# Altium schematic sheet Commodore CPM Modul.SchDoc("Commodore CPM Modul")
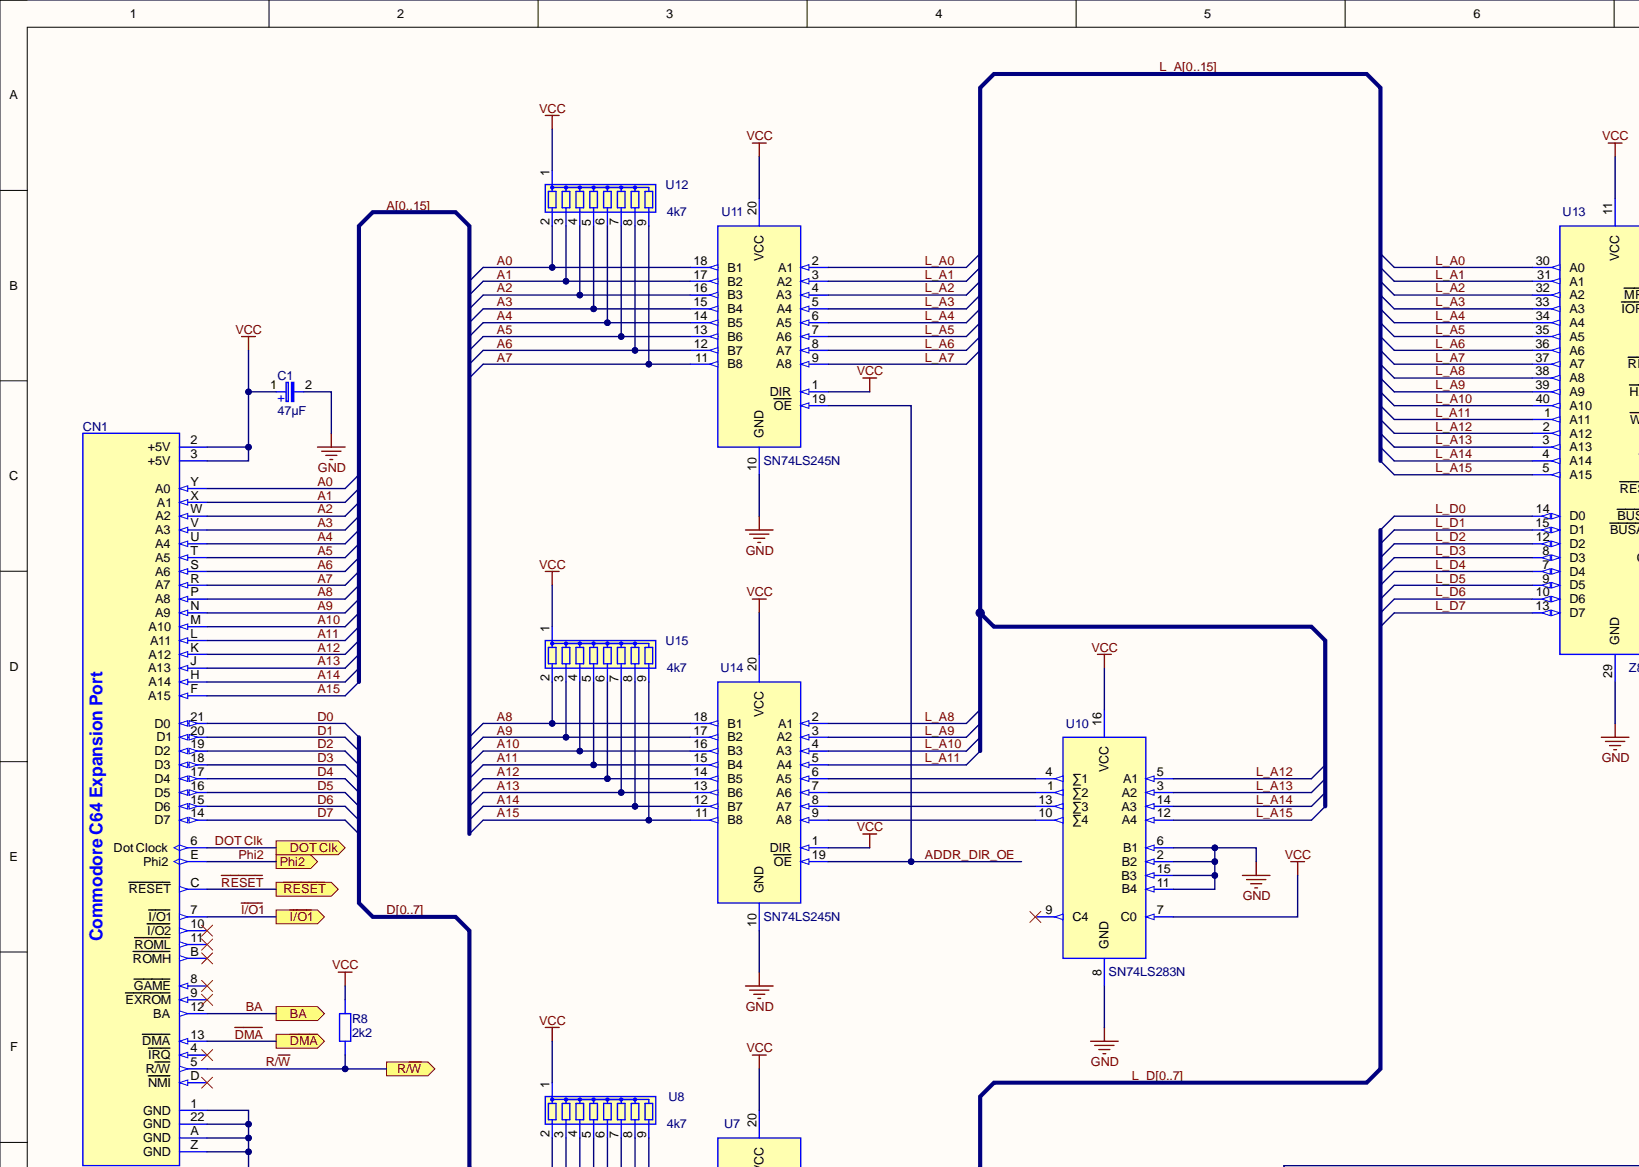
[diagram p0: Commodore CPM Modul.SchDoc, top left]
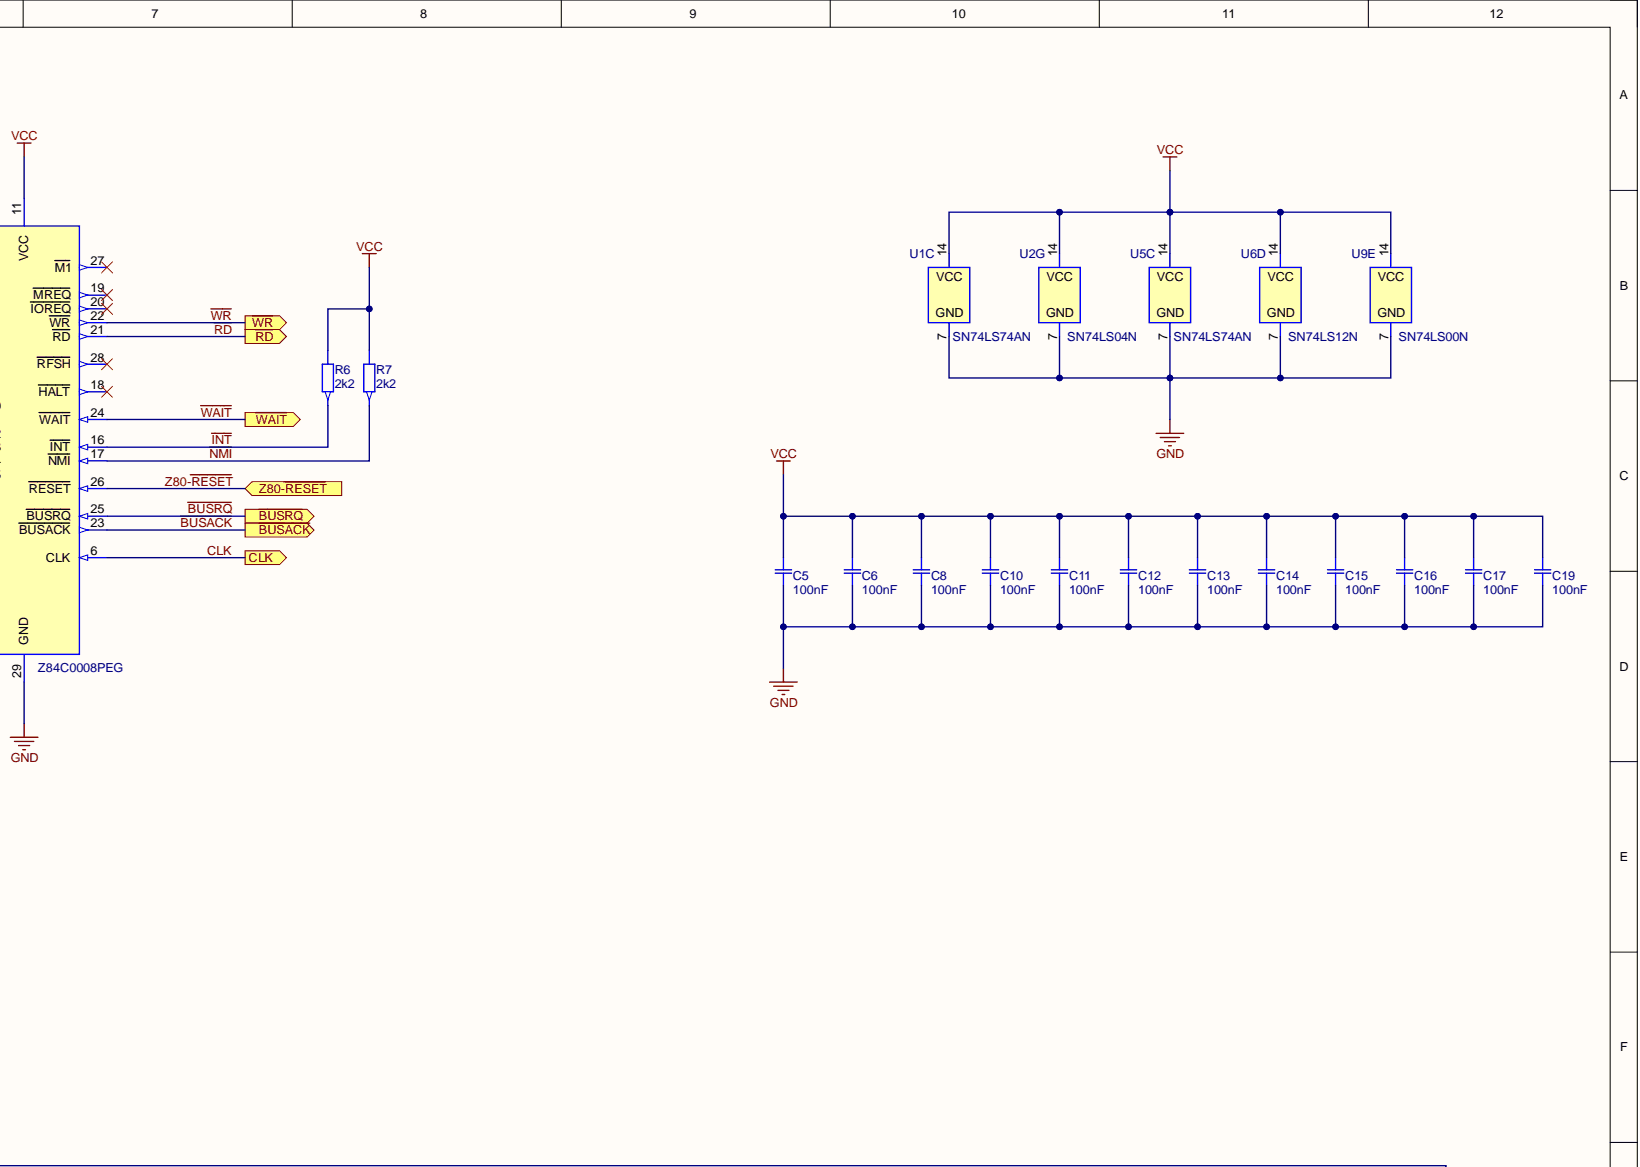
[diagram p1: Commodore CPM Modul.SchDoc, top right]
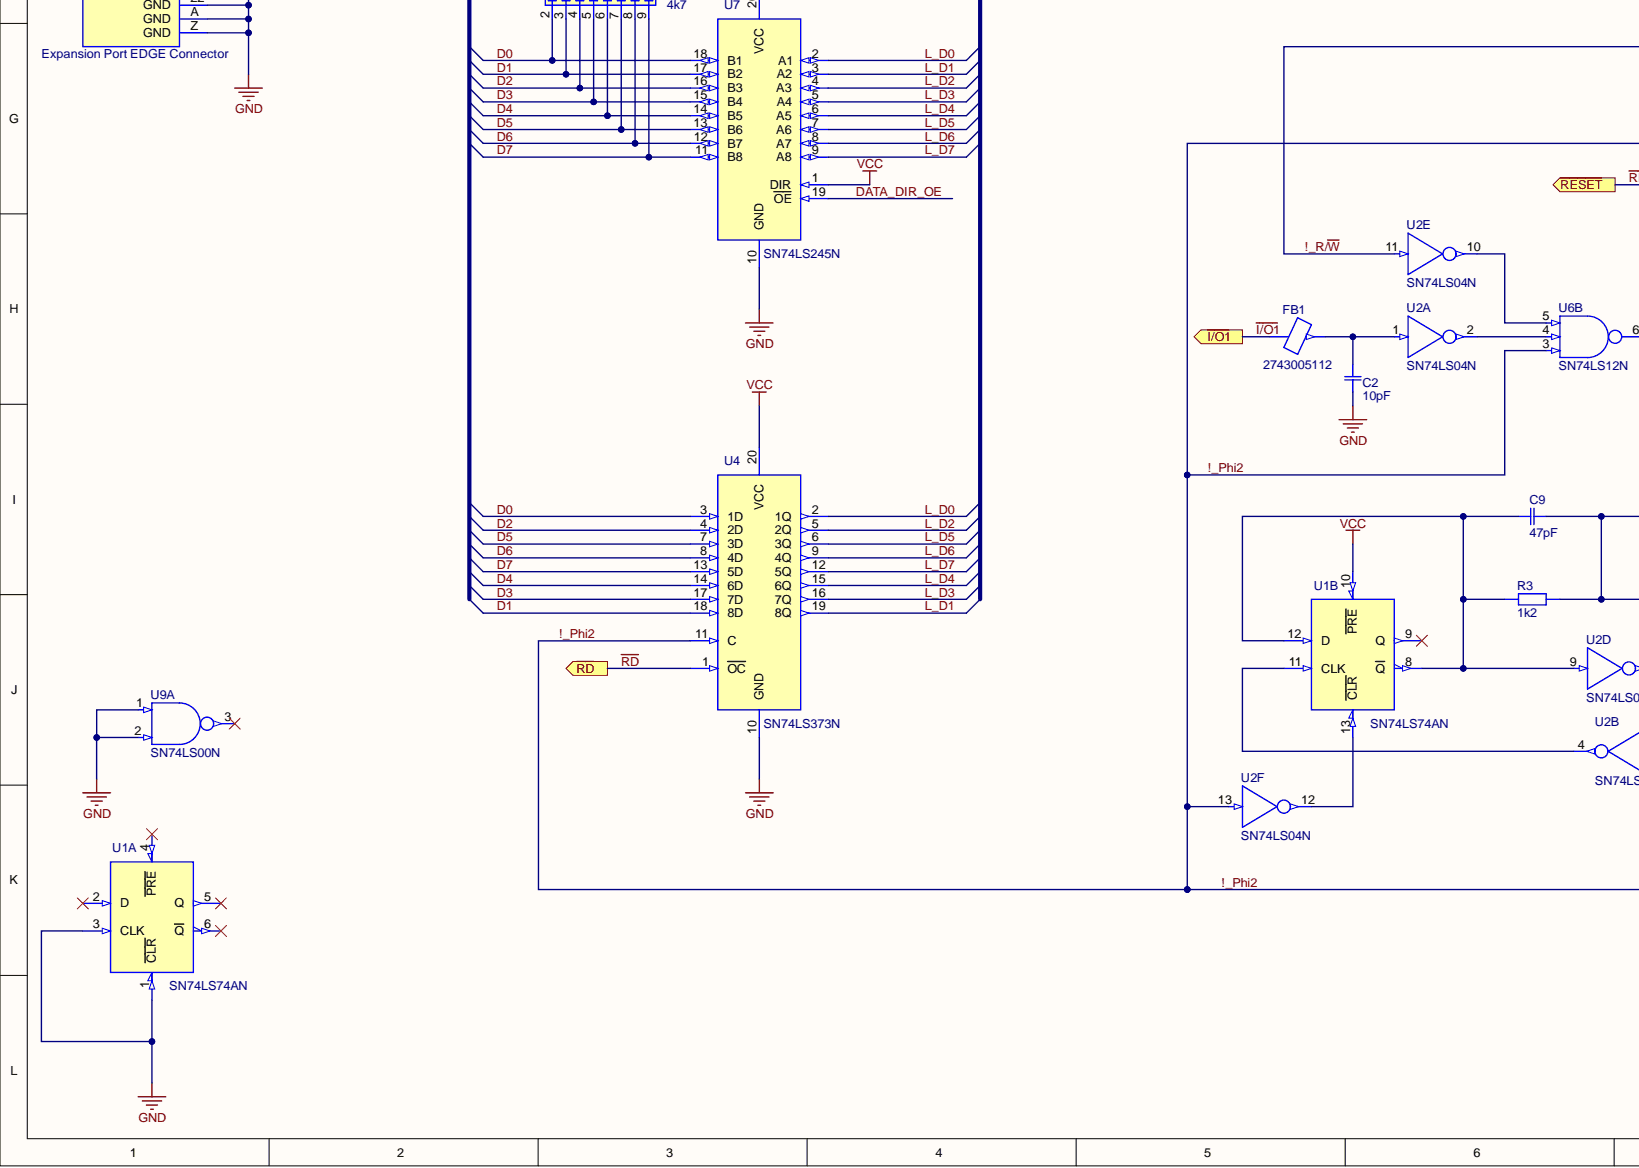
[diagram p2: Commodore CPM Modul.SchDoc, bottom left]
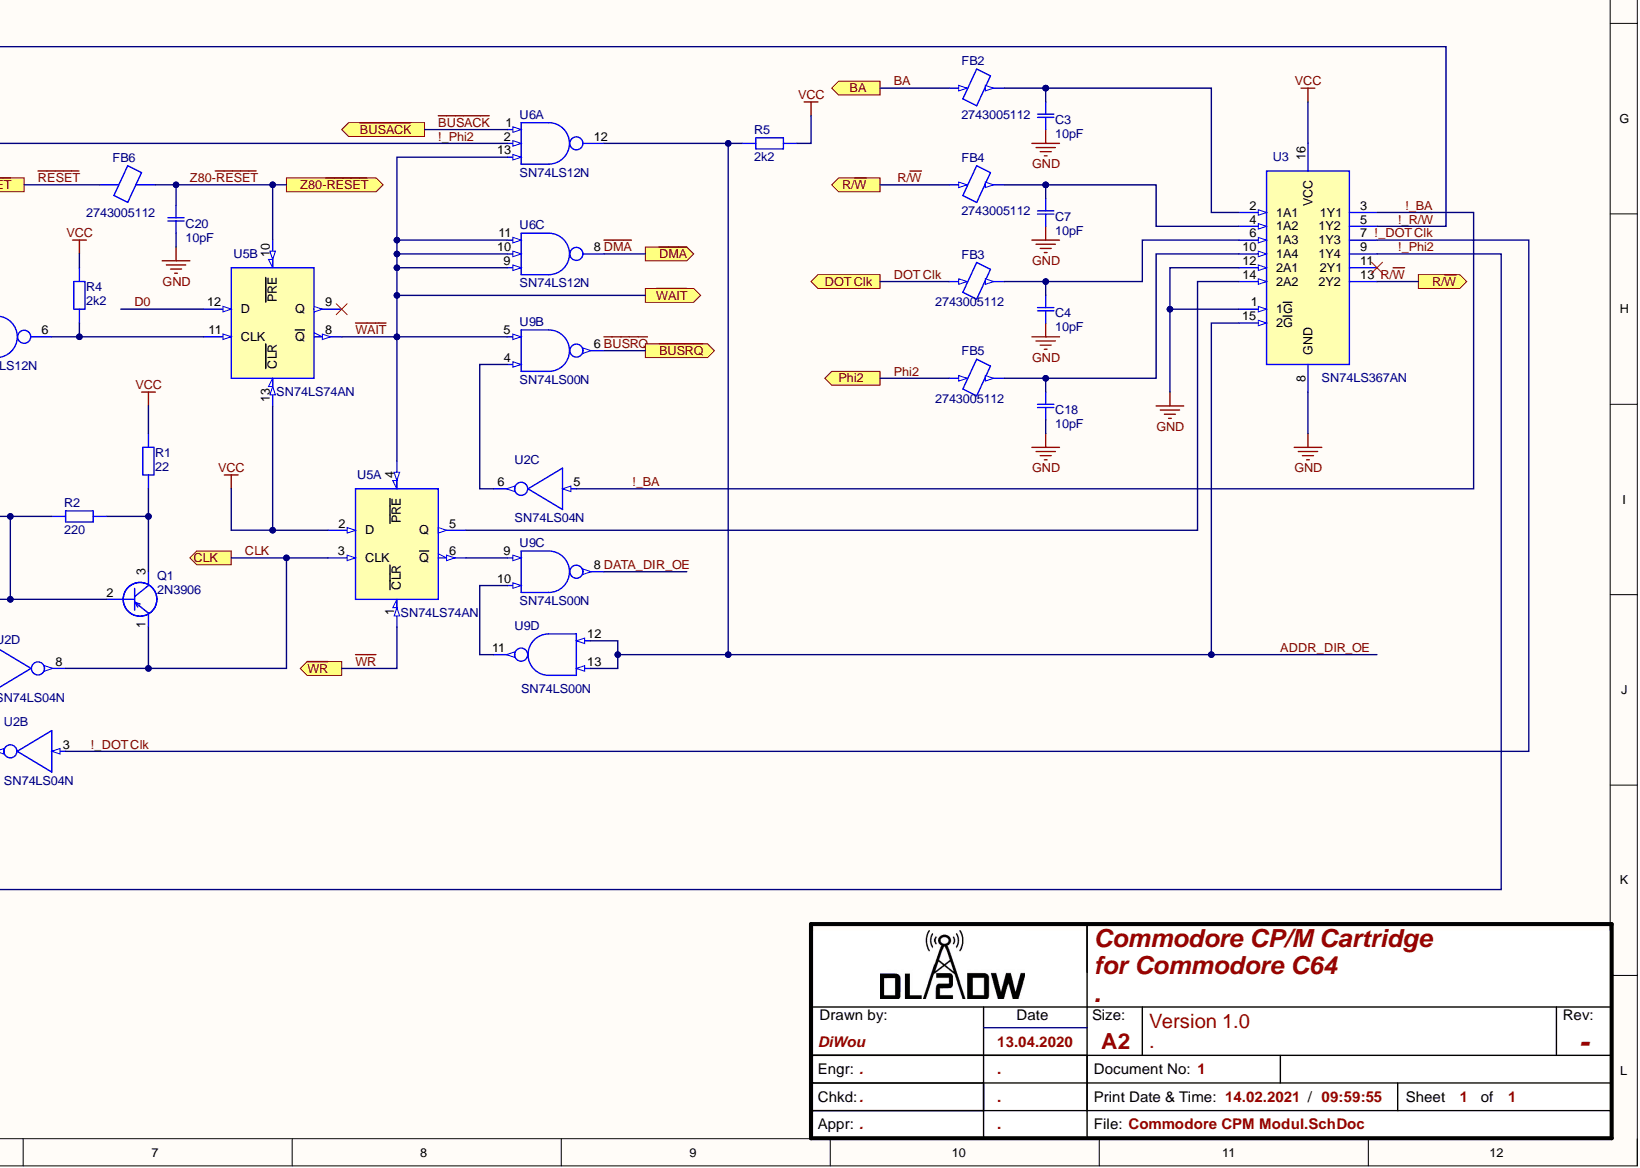
[diagram p3: Commodore CPM Modul.SchDoc, bottom right]

## Parts
C1  47µF  [CAP Elec 47uF 25V Axial - Vishay MAL203036479E3]
C2  10pF  [CAP CER 10PF 50V C0G/NP0 AXIAL Vishay A100J15C0GF5TAA]
C3  10pF  [CAP CER 10PF 50V C0G/NP0 AXIAL Vishay A100J15C0GF5TAA]
C4  10pF  [CAP CER 10PF 50V C0G/NP0 AXIAL Vishay A100J15C0GF5TAA]
C5  100nF  [CAP CER 0.1UF 50V X7R RADIAL Kemet C330C104J5R5TA]
C6  100nF  [CAP CER 0.1UF 50V X7R RADIAL Kemet C330C104J5R5TA]
C7  10pF  [CAP CER 10PF 50V C0G/NP0 AXIAL Vishay A100J15C0GF5TAA]
C8  100nF  [CAP CER 0.1UF 50V X7R RADIAL Kemet C330C104J5R5TA]
C9  47pF  [CAP CER 47PF 50V C0G/NP0 AXIAL Vishay A470J15C0GF5TAA]
C10  100nF  [CAP CER 0.1UF 50V X7R RADIAL Kemet C330C104J5R5TA]
C11  100nF  [CAP CER 0.1UF 50V X7R RADIAL Kemet C330C104J5R5TA]
C12  100nF  [CAP CER 0.1UF 50V X7R RADIAL Kemet C330C104J5R5TA]
C13  100nF  [CAP CER 0.1UF 50V X7R RADIAL Kemet C330C104J5R5TA]
C14  100nF  [CAP CER 0.1UF 50V X7R RADIAL Kemet C330C104J5R5TA]
C15  100nF  [CAP CER 0.1UF 50V X7R RADIAL Kemet C330C104J5R5TA]
C16  100nF  [CAP CER 0.1UF 50V X7R RADIAL Kemet C330C104J5R5TA]
C17  100nF  [CAP CER 0.1UF 50V X7R RADIAL Kemet C330C104J5R5TA]
C18  10pF  [CAP CER 10PF 50V C0G/NP0 AXIAL Vishay A100J15C0GF5TAA]
C19  100nF  [CAP CER 0.1UF 50V X7R RADIAL Kemet C330C104J5R5TA]
C20  10pF  [CAP CER 10PF 50V C0G/NP0 AXIAL Vishay A100J15C0GF5TAA]
CN1  Expansion Port EDGE Connector  [C64 Expansion Port EDGE Connector]  (no BOM)
FB1  2743005112  [Ferrite Bead Fair Rite 2743005112 axial 10,16mm]
FB2  2743005112  [Ferrite Bead Fair Rite 2743005112 axial 10,16mm]
FB3  2743005112  [Ferrite Bead Fair Rite 2743005112 axial 10,16mm]
FB4  2743005112  [Ferrite Bead Fair Rite 2743005112 axial 10,16mm]
FB5  2743005112  [Ferrite Bead Fair Rite 2743005112 axial 10,16mm]
FB6  2743005112  [Ferrite Bead Fair Rite 2743005112 axial 10,16mm]
Q1  2N3906  [2N3906]
R1  22  [22 Ohm R Axial DIN0207 L6.3mm D2.5mm P10.16mm Horizontal]
R2  220  [220 Ohm R Axial DIN0207 L6.3mm D2.5mm P10.16mm Horizontal]
R3  1k2  [1,2 kOhm R Axial DIN0207 L6.3mm D2.5mm P10.16mm Horizontal]
R4  2k2  [2,2 kOhm R Axial DIN0207 L6.3mm D2.5mm P10.16mm Horizontal]
R5  2k2  [2,2 kOhm R Axial DIN0207 L6.3mm D2.5mm P10.16mm Horizontal]
R6  2k2  [2,2 kOhm R Axial DIN0207 L6.3mm D2.5mm P10.16mm Horizontal]
R7  2k2  [2,2 kOhm R Axial DIN0207 L6.3mm D2.5mm P10.16mm Horizontal]
R8  2k2  [2,2 kOhm R Axial DIN0207 L6.3mm D2.5mm P10.16mm Horizontal]
U1  SN74LS74AN  [SN74LS74N with Socket]  (parts A,B,C)
U2  SN74LS04N  [SN74LS04N with Socket]  (parts A,B,C,D,E,F,G)
U3  SN74LS367AN  [SN74LS367A with Socket]
U4  SN74LS373N  [SN74LS373N with Socket]
U5  SN74LS74AN  [SN74LS74N with Socket]  (parts A,B,C)
U6  SN74LS12N  [SN74LS12N with Socket]  (parts A,B,C,D)
U7  SN74LS245N  [SN74LS245N with Socket]
U8  4k7  [4k7 x8 Resistor Array SIL Royal 9A472G]
U9  SN74LS00N  [SN74LS00N with Socket]  (parts A,B,C,D,E)
U10  SN74LS283N  [SN74LS283N with Socket]
U11  SN74LS245N  [SN74LS245N with Socket]
U12  4k7  [4k7 x8 Resistor Array SIL Royal 9A472G]
U13  Z84C0008PEG  [Zilog Z80 CPU with Socket]
U14  SN74LS245N  [SN74LS245N with Socket]
U15  4k7  [4k7 x8 Resistor Array SIL Royal 9A472G]

## Ports
BA, CLK, DOT Clk, Phi2, R/~{W}, Z80-~{RESET}, ~{BUSACK}, ~{BUSRQ}, ~{DMA}, ~{I/O1}, ~{RD}, ~{RESET}, ~{WAIT}, ~{WR}

## Nets
GND: C1.2 C2.1 C3.2 C4.2 C5.1 C6.1 C7.1 C8.1 C10.1 C11.1 C12.1 C13.1 C14.1 C15.1 C16.1 C17.1 C18.1 C19.1 C20.1 CN1.1(GND) CN1.22(GND) CN1.A(GND) CN1.Z(GND) U1.1(CLR) U1.3(CLK) U1.7 U2.7 U3.1(1G) U3.8(GND) U3.12(2A1) U4.10(GND) U5.7 U6.7 U7.10(GND) U9.1 U9.2 U9.7 U10.2(B2) U10.6(B1) U10.8(GND) U10.11(B4) U10.15(B3) U11.10(GND) U13.29(GND) U14.10(GND)
VCC: C1.1 C5.2 C6.2 C8.2 C10.2 C11.2 C12.2 C13.2 C14.2 C15.2 C16.2 C17.2 C19.2 CN1.2(+5V) CN1.3(+5V) R1.2 R4.2 R5.2 R6.1 R7.1 R8.1 U1.10(PRE) U1.14 U2.14 U3.16(VCC) U4.20(VCC) U5.2(D) U5.13(CLR) U5.14 U6.14 U7.1(DIR) U7.20(VCC) U8.1 U9.14 U10.7(C0) U10.16(VCC) U11.1(DIR) U11.20(VCC) U12.1 U13.11(VCC) U14.1(DIR) U14.20(VCC) U15.1
!_BA: U2.5 U3.3(1Y1)
!_DOT Clk: U2.3 U3.7(1Y3)
!_Phi2: U2.13 U3.9(1Y4) U4.11(C) U6.2 U6.3
!_R/~{W}: U2.11 U3.5(1Y2)
A0: CN1.Y(A0) U11.18(B1) U12.2  [also labelled A]
A1: CN1.X(A1) U11.17(B2) U12.3  [also labelled A]
A2: CN1.W(A2) U11.16(B3) U12.4  [also labelled A]
A3: CN1.V(A3) U11.15(B4) U12.5  [also labelled A]
A4: CN1.U(A4) U11.14(B5) U12.6  [also labelled A]
A5: CN1.T(A5) U11.13(B6) U12.7  [also labelled A]
A6: CN1.S(A6) U11.12(B7) U12.8  [also labelled A]
A7: CN1.R(A7) U11.11(B8) U12.9  [also labelled A]
A8: CN1.P(A8) U14.18(B1) U15.2  [also labelled A]
A9: CN1.N(A9) U14.17(B2) U15.3  [also labelled A]
A10: CN1.M(A10) U14.16(B3) U15.4  [also labelled A]
A11: CN1.L(A11) U14.15(B4) U15.5  [also labelled A]
A12: CN1.K(A12) U14.14(B5) U15.6  [also labelled A]
A13: CN1.J(A13) U14.13(B6) U15.7  [also labelled A]
A14: CN1.H(A14) U14.12(B7) U15.8  [also labelled A]
A15: CN1.F(A15) U14.11(B8) U15.9  [also labelled A]
ADDR_DIR_OE: R5.1 U3.15(2G) U6.12 U9.12 U9.13 U11.19(OE) U14.19(OE)
~{BUSACK}: U6.1 U13.23(BUSACK) ; port ~{BUSACK}
~{BUSRQ}: U9.6 U13.25(BUSRQ) ; port ~{BUSRQ}
BA: CN1.12(BA) FB2.1 ; port BA
CLK: Q1.1 U2.8 U5.3(CLK) U13.6(CLK) ; port CLK
D0: CN1.21(D0) U4.3(1D) U5.12(D) U7.18(B1) U8.2  [also labelled D]
D1: CN1.20(D1) U4.18(8D) U7.17(B2) U8.3  [also labelled D]
D2: CN1.19(D2) U4.4(2D) U7.16(B3) U8.4  [also labelled D]
D3: CN1.18(D3) U4.17(7D) U7.15(B4) U8.5  [also labelled D]
D4: CN1.17(D4) U4.14(6D) U7.14(B5) U8.6  [also labelled D]
D5: CN1.16(D5) U4.7(3D) U7.13(B6) U8.7  [also labelled D]
D6: CN1.15(D6) U4.8(4D) U7.12(B7) U8.8  [also labelled D]
D7: CN1.14(D7) U4.13(5D) U7.11(B8) U8.9  [also labelled D]
~{DMA}: CN1.13(DMA) U6.8 ; port ~{DMA}
DATA_DIR_OE: U7.19(OE) U9.8
DOT Clk: CN1.6(Dot_Clock) FB3.1 ; port DOT Clk
~{I/O1}: CN1.7(I/O1) FB1.1 ; port ~{I/O1}
~{INT}: R6.2 U13.16(INT)
L_A0: U11.2(A1) U13.30(A0)  [also labelled L_A]
L_A1: U11.3(A2) U13.31(A1)  [also labelled L_A]
L_A2: U11.4(A3) U13.32(A2)  [also labelled L_A]
L_A3: U11.5(A4) U13.33(A3)  [also labelled L_A]
L_A4: U11.6(A5) U13.34(A4)  [also labelled L_A]
L_A5: U11.7(A6) U13.35(A5)  [also labelled L_A]
L_A6: U11.8(A7) U13.36(A6)  [also labelled L_A]
L_A7: U11.9(A8) U13.37(A7)  [also labelled L_A]
L_A8: U13.38(A8) U14.2(A1)  [also labelled L_A]
L_A9: U13.39(A9) U14.3(A2)  [also labelled L_A]
L_A10: U13.40(A10) U14.4(A3)  [also labelled L_A]
L_A11: U13.1(A11) U14.5(A4)  [also labelled L_A]
L_A12: U10.5(A1) U13.2(A12)  [also labelled L_A]
L_A13: U10.3(A2) U13.3(A13)  [also labelled L_A]
L_A14: U10.14(A3) U13.4(A14)  [also labelled L_A]
L_A15: U10.12(A4) U13.5(A15)  [also labelled L_A]
L_D0: U4.2(1Q) U7.2(A1) U13.14(D0)  [also labelled L_D]
L_D1: U4.19(8Q) U7.3(A2) U13.15(D1)  [also labelled L_D]
L_D2: U4.5(2Q) U7.4(A3) U13.12(D2)  [also labelled L_D]
L_D3: U4.16(7Q) U7.5(A4) U13.8(D3)  [also labelled L_D]
L_D4: U4.15(6Q) U7.6(A5) U13.7(D4)  [also labelled L_D]
L_D5: U4.6(3Q) U7.7(A6) U13.9(D5)  [also labelled L_D]
L_D6: U4.9(4Q) U7.8(A7) U13.10(D6)  [also labelled L_D]
L_D7: U4.12(5Q) U7.9(A8) U13.13(D7)  [also labelled L_D]
~{NMI}: R7.2 U13.17(NMI)
Phi2: CN1.E(Phi2) FB5.1 ; port Phi2
R/~{W}: CN1.5(R/W) FB4.1 R8.2 U3.13(2Y2) ; port R/~{W}
~{RD}: U4.1(OC) U13.21(RD) ; port ~{RD}
~{RESET}: CN1.C(RESET) FB6.1 ; port ~{RESET}
~{WAIT}: U5.4(PRE) U5.8(Q) U6.9 U6.10 U6.11 U6.13 U9.5 U13.24(WAIT) ; port ~{WAIT}
~{WR}: U5.1(CLR) U13.22(WR) ; port ~{WR}
Z80-~{RESET}: C20.2 FB6.2 U5.10(PRE) U13.26(RESET) ; port Z80-~{RESET}
NetC2_2: C2.2 FB1.2 U2.1
NetC3_1: C3.1 FB2.2 U3.2(1A1)
NetC4_1: C4.1 FB3.2 U3.6(1A3)
NetC7_2: C7.2 FB4.2 U3.4(1A2)
NetC9_1: C9.1 R3.2 U1.8(Q) U1.12(D) U2.9
NetC9_2: C9.2 Q1.2 R2.2 R3.1
NetC18_2: C18.2 FB5.2 U3.10(1A4)
NetQ1_3: Q1.3 R1.1 R2.1
NetR4_1: R4.1 U5.11(CLK) U6.6
NetU1_11: U1.11(CLK) U2.4
NetU1_13: U1.13(CLR) U2.12
NetU2_2: U2.2 U6.4
NetU2_6: U2.6 U9.4
NetU2_10: U2.10 U6.5
NetU3_14: U3.14(2A2) U5.5(Q)
NetU5_6: U5.6(Q) U9.9
NetU9_10: U9.10 U9.11
NetU10_1: U10.1(∑2) U14.7(A6)
NetU10_4: U10.4(∑1) U14.6(A5)
NetU10_10: U10.10(∑4) U14.9(A8)
NetU10_13: U10.13(∑3) U14.8(A7)

Not connected: CN1 4(IRQ) 8(GAME) 9(EXROM) 10(I/O2) 11(ROML) B(ROMH) D(NMI); U1 2(D) 4(PRE) 5(Q) 6(Q) 9(Q); U3 11(2Y1); U5 9(Q); U9 3; U10 9(C4); U13 18(HALT) 19(MREQ) 20(IOREQ) 27(M1) 28(RFSH)

## Library data of the parts
C1 = CMP-Capacitors-Electrolyt_Capacitors00023-1: Cap Aluminum 47uF 25V -10% to 50% (6 X 10mm) Axial 4.8 Ohm 100mA 3000 hr 85C Taped in Box; ESR (Equivalent Series Resistance): 4.8R; Impedance: 1.9R; Lead Diameter: 0.6mm; Leakage Current: 12uA; Life (Hours): 3000Hour; Polarity: Polar; Capacitance: 47uF; Case/Package: Axial; Component Kind: Standard; Construction: AXIAL; Diameter: 6mm; Height: 10mm; Lead Pitch: 15mm; Length: 10mm; Library Name: PCB-Tech Cloud; Max Operating Temperature: 85°C; Min Operating Temperature: -40°C; Mount: Through Hole; Package Quantity: 1000; Packaging: Tape and Reel; Radiation Hardening: No; REACH SVHC: Unknown; Ripple Current: 100mA; RoHS Compliant: Yes; Series: 030 AS; Termination: Axial; Tolerance: 50%; Voltage: 25V; Voltage Rating: 25V; Voltage Rating (DC): 25V
C2-C4 C7 C18 C20 = CMP-Capacitors-Ceramic_Capacitors-00047-1: Cap Ceramic 10pF 50V C0G 5% Axial 125C T/R; Capacitance: 10pF; Case/Package: Axial; Component Kind: Standard; Construction: AXIAL; Dielectric: C0G; Length: 0.15inch; Library Name: PCB-Tech Cloud; Max Operating Temperature: 125°C; Min Operating Temperature: -55°C; Mount: Through Hole; Package Quantity: 7000; Packaging: Tape and Reel; Radiation Hardening: No; RoHS Compliant: Yes; Series: A; Termination: Axial; Tolerance: 5%; Voltage Rating: 50V
C5 C6 C8 C10-C17 C19 = CMP-Capacitors-Ceramic_Capacitors-00046-1: Cap Ceramic 0.1uF 50V X7R 5% Radial 5.08mm 125C Bulk; Capacitance: 100nF; Case/Package: Dipped; Component Kind: Standard; Construction: RADIAL; Depth: 3.81mm; Dielectric: X7R; Lead Pitch: 5.08mm; Length: 7.62mm; Library Name: PCB-Tech Cloud; Max Operating Temperature: 125°C; Min Operating Temperature: -55°C; Mount: Through Hole; Packaging: Bulk; Radiation Hardening: No; RoHS Compliant: Yes; Termination: Radial; Tolerance: 5%
C9 = CMP-Capacitors-Ceramic_Capacitors-00048-1: Cap Ceramic 47pF 50V C0G 5% Axial 125C T/R; Capacitance: 47pF; Case/Package: Axial; Component Kind: Standard; Construction: AXIAL; Dielectric: C0G; Length: 0.15inch; Library Name: PCB-Tech Cloud; Max Operating Temperature: 125°C; Min Operating Temperature: -55°C; Mount: Through Hole; Package Quantity: 7000; Packaging: Tape and Reel; Radiation Hardening: No; RoHS Compliant: Yes; Series: A; Termination: Axial; Tolerance: 5%; Voltage Rating: 50V
CN1 = CMP-Commodore-00008-4: Expansion Port EDGE Connector for Interface Cards; Library Name: PCB-Tech Cloud
FB1-FB6 = CMP-Inductors-00000-10: Ferrite Beads Axial 91Ohm 100MHz T/R; Impedance: 91R; Shielding: Unshielded; Case/Package: Axial; Component Kind: Standard; Diameter: 3.5mm; Length: 6mm; Library Name: PCB-Tech Cloud; Max Operating Temperature: 125°C; Min Operating Temperature: -55°C; Package Quantity: 4500; Packaging: Tape and Reel; Termination: Axial; Test Frequency: 100MHz
Q1 = CMP-Transistors-00014-1: Bipolar Transistor PNP Amplifier/Switch 40V 200mA 3-Pin TO-92 Through Hole Box; Collector Emitter Saturation Voltage: 400mV; Element Configuration: Single; Emitter Base Voltage (VEBO): 5V; Gain Bandwidth Product: 250MHz; hFE Min: 100; Max Collector Current: 50nA; Max Power Dissipation: 625mW; Case/Package: TO-92; Collector Base Voltage (VCBO): 40V; Collector Emitter Breakdown Voltage: 40V; Collector Emitter Voltage (VCEO): 40V; Collector-emitter Voltage-Max: 40V; Component Kind: Standard; Continuous Collector Current: 200mA; Lead Free: Lead Free; Library Name: PCB-Tech Cloud; Mount: Through Hole; Package Quantity: 2500; Packaging: Bulk; Polarity: PNP; RoHS Compliant: Yes; Series: -; Transition Frequency: 250MHz
R1 = CMP-Resistors-Yageo_THT_DIN_0207-00032-1: RES 22 OHM 1/4W 5% AXIAL; Max Power Dissipation: 250mW; Resistance: 22R; Temperature Coefficient: 500ppm/°C; Case/Package: Axial; Component Kind: Standard; Composition: Carbon Film; Length: 0.248inch; Library Name: PCB-Tech Cloud; Max Operating Temperature: 155°C; Min Operating Temperature: -55°C; Number of Terminations: 2; Package Quantity: 200; Packaging: Bulk; Tolerance: 5%
R2 = CMP-Resistors-Yageo_THT_DIN_0207-00056-1: RES 220 OHM 1/4W 5% AXIAL; Max Power Dissipation: 250mW; Resistance: 220R; Temperature Coefficient: 500ppm/°C; Case/Package: Axial; Component Kind: Standard; Composition: Carbon Film; Length: 0.248inch; Library Name: PCB-Tech Cloud; Max Operating Temperature: 155°C; Min Operating Temperature: -55°C; Number of Terminations: 2; Package Quantity: 200; Packaging: Bulk; Tolerance: 5%
R3 = CMP-Resistors-Yageo_THT_DIN_0207-00074-1: RES 1.2K OHM 1/4W 5% AXIAL; Max Power Dissipation: 250mW; Resistance: 1.2kR; Temperature Coefficient: 500ppm/°C; Case/Package: Axial; Component Kind: Standard; Composition: Carbon Film; Lead Free: Lead Free; Length: 0.248inch; Library Name: PCB-Tech Cloud; Max Operating Temperature: 155°C; Min Operating Temperature: -55°C; Number of Terminations: 2; Package Quantity: 200; Packaging: Bulk; Tolerance: 5%
R4-R8 = CMP-Resistors-Yageo_THT_DIN_0207-00080-1: RES 2.2K OHM 1/4W 5% AXIAL; Max Power Dissipation: 250mW; Resistance: 2.2kR; Temperature Coefficient: 500ppm/°C; Case/Package: Axial; Component Kind: Standard; Composition: Carbon Film; Lead Free: Lead Free; Length: 0.248inch; Library Name: PCB-Tech Cloud; Max Operating Temperature: 155°C; Min Operating Temperature: -55°C; Mount: Through Hole; Number of Terminations: 2; Package Quantity: 200; Packaging: Bulk; Tolerance: 5%
U1 U5 = CMP-ICs-74xx-series-00042-2: TEXAS INSTRUMENTS   SN74LS74AN   Flip-Flop, with Set and Reset, Complementary Output, Differential, Positive Edge, D, 13 ns, 33 MHz; Frequency: 33MHz; High Level Output Current: -400uA; Logic Function: AND,D-Type,Flip-Flop,Latch; Low Level Output Current: 8mA; Max Supply Voltage: 5.25V; Min Supply Voltage: 4.75V; Nominal Supply Current: 25mA; Number of Bits: 2; Number of Bits per Element: 1; Number of Input Lines: 3; Operating Supply Voltage: 5V; Output Current: 16mA; Output Type: TTL; Polarity: Non-Inverting; Propagation Delay: 40ns; Turn-On Delay Time: 13ns; Case/Package: PDIP; Clock Edge Trigger Type: Positive Edge; Component Kind: Standard; Contact Plating: Gold; Height: 4.57mm; Lead Free: Lead Free; Length: 19.3mm; Library Name: PCB-Tech Cloud; Max Frequency: 33MHz; Max Operating Temperature: 70°C; Min Operating Temperature: 0°C; Mount: Through Hole; Number of Channels: 2; Number of Circuits: 2; Number of Elements: 2; Number of Output Lines: 1; Number of Pins: 14; Package Quantity: 25; Packaging: Rail/Tube; Radiation Hardening: No; REACH SVHC: No SVHC; Weight: 0.032734oz; Width: 6.35mm
U2 = CMP-ICs-74xx-series-00006-2: IC HEX INVERTER 14-DIP; High Level Output Current: -400uA; Logic Function: Buffer,Inverter; Low Level Output Current: 8mA; Max Supply Voltage: 5.25V; Min Supply Voltage: 4.75V; Nominal Supply Current: 100uA; Number of Bits: 6; Number of Inputs: 1; Operating Supply Voltage: 5V; Propagation Delay: 15ns; Schmitt Trigger Input: No; Turn-On Delay Time: 22ns; Case/Package: PDIP; Component Kind: Standard; Contact Plating: Gold; Height: 4.57mm; Lead Free: Lead Free; Length: 19.3mm; Library Name: PCB-Tech Cloud; Max Operating Temperature: 70°C; Max Output Current: 8mA; Min Operating Temperature: 0°C; Mount: Through Hole; Number of Circuits: 6; Number of Elements: 6; Number of Gates: 6; Number of Output Lines: 6; Number of Pins: 14; Output Current: 8mA; Package Quantity: 25; Packaging: Rail/Tube; Radiation Hardening: No; REACH SVHC: No SVHC; Termination: Through Hole; Weight: 0.032734oz; Width: 6.35mm
U3 = CMP-ICs-74xx-series-00077-1: I.C. SN74LS 367 AN; High Level Output Current: -2.6mA; Logic Function: Buffer; Low Level Output Current: 24mA; Max Supply Voltage: 5.25V; Min Supply Voltage: 4.75V; Nominal Supply Current: 100uA; Number of Bits: 6; Number of Elements: 2; Operating Supply Voltage: 5V; Output Type: 3-STATE; Polarity: Non-Inverting; Propagation Delay: 40ns; Quiescent Current: 12mA; Case/Package: PDIP; Component Kind: Standard; Height: 4.57mm; Lead Free: Lead Free; Length: 19.3mm; Library Name: PCB-Tech Cloud; Max Operating Temperature: 70°C; Min Operating Temperature: 0°C; Mount: Through Hole; Number of Channels: 6; Number of Circuits: 6; Number of Inputs: 6; Number of Outputs: 6; Number of Pins: 16; Output Current: 24mA; Packaging: Box; REACH SVHC: No SVHC; RoHS Compliant: No; Technology: BIPOLAR; Width: 6.35mm
U4 = CMP-ICs-74xx-series-00062-1: Latch Transparent 3-ST 8-CH D-Type 20-Pin PDIP Tube; High Level Output Current: -2.6mA; Independent Circuits: 1; Logic Function: D-Type,Latch,Flip-Flop; Low Level Output Current: 24mA; Max Supply Voltage: 5.25V; Min Supply Voltage: 4.75V; Number of Bits: 8; Number of Input Lines: 3; Operating Supply Current: 40mA; Operating Supply Voltage: 5V; Polarity: Non-Inverting; Propagation Delay: 18ns; Turn-On Delay Time: 12ns; Case/Package: PDIP; Component Kind: Standard; Contact Plating: Gold; Height: 4.57mm; Lead Free: Lead Free; Length: 24.33mm; Library Name: PCB-Tech Cloud; Max Operating Temperature: 70°C; Min Operating Temperature: 0°C; Mount: Through Hole; Number of Channels: 8; Number of Circuits: 8; Number of Elements: 1; Number of Output Lines: 1; Number of Outputs: 8; Number of Pins: 20; Output Current: 24mA; Package Quantity: 20; Packaging: Rail/Tube; Quiescent Current: 24mA; Radiation Hardening: No; REACH SVHC: No SVHC; Weight: 0.042297oz; Width: 6.35mm
U6 = CMP-ICs-74xx-series-00012-2: Logic Gates Triple 3-input Positive-NAND gates with open collector outputs 14-PDIP 0 to 70; Logic Function: NAND; Case/Package: PDIP; Component Kind: Standard; Lead Free: Contains Lead; Library Name: PCB-Tech Cloud
U7 U11 U14 = CMP-ICs-74xx-series-00046-2: IC TXRX NON-INVERT 5.25V 20DIP; Direction: Bidirectional; High Level Output Current: -15mA; Logic Function: Transceiver,Inverting; Low Level Output Current: 24mA; Min Supply Voltage: 4.75V; Number of Bits: 8; Number of Elements: 1; Operating Supply Voltage: 5V; Polarity: Non-Inverting; Propagation Delay: 12ns; Quiescent Current: 62mA; Case/Package: PDIP; Component Kind: Standard; Contact Plating: Gold; Height: 4.57mm; Lead Free: Lead Free; Length: 24.33mm; Library Name: PCB-Tech Cloud; Max Operating Temperature: 70°C; Max Supply Voltage: 5.25V; Min Operating Temperature: 0°C; Mount: Through Hole; Number of Bits per Element: 8; Number of Channels: 8; Number of Circuits: 8; Number of Outputs: 8; Number of Pins: 20; Number of Transceivers: 8; Package Quantity: 20; Packaging: Rail/Tube; Radiation Hardening: No; REACH SVHC: No SVHC; Termination: Through Hole; Turn-On Delay Time: 12ns; Weight: 0.042297oz; Width: 6.35mm
U8 U12 U15 = CMP-Resistors-Resistor_Array00016-1: Resistor Networks & Arrays 9pin 4.7Kohms 2% Bussed; Number of Contacts: 9; Number of Elements: 10; Number of Resistors: 8; Power Per Element: 200mW; Power Rating: 1.12W; Resistance: 4.7kR; Temperature Coefficient: 100ppm/°C; Voltage Rating: 100V; Case/Package: SIP; Circuit Type: Bussed; Component Kind: Standard; Contact Plating: Tin,Lead; Depth: 2.49mm; Height: 0.195inch; Lead Free: Contains Lead; Lead Pitch: 2.54mm; Length: 22.61mm; Library Name: PCB-Tech Cloud; Max Operating Temperature: 125°C; Military Standard: Not; Min Operating Temperature: -55°C; Mount: Through Hole; Number of Pins: 9; Number of Terminals: 10; Number of Terminals/Pins: 9; Package Quantity: 1000; Packaging: Bulk; Radiation Hardening: No; REACH SVHC: Unknown; Resistance Tolerance: 2%; RoHS Compliant: No; Series: CSC; Technology: THICK FILM; Terminal Pitch: 2.54mm; Termination: Pin; Thickness: 2.49mm; Tolerance: 2%; Voltage Rating (DC): 100V; Width: 0.098inch; Working Voltage: 100V
U9 = CMP-ICs-74xx-series-00000-3: IC, 74LS, 74LS00, DIP14, 5.25V; High Level Output Current: -400uA; Logic Function: NAND; Low Level Output Current: 8mA; Min Supply Voltage: 4.75V; Number of Bits: 4; Number of Input Lines: 2; Number of Output Lines: 1; Operating Supply Voltage: 5V; Output Current: 16mA; Propagation Delay: 15ns; Quiescent Current: 2.4mA; Schmitt Trigger Input: No; Case/Package: PDIP; Component Kind: Standard; Contact Plating: Gold; Height: 4.57mm; Lead Free: Lead Free; Length: 19.3mm; Library Name: PCB-Tech Cloud; Max Operating Temperature: 70°C; Max Supply Voltage: 5.25V; Min Operating Temperature: 0°C; Mount: Through Hole; Number of Circuits: 4; Number of Elements: 4; Number of Gates: 4; Number of Inputs: 2; Number of Outputs: 1; Number of Pins: 14; Package Quantity: 25; Packaging: Rail/Tube; Radiation Hardening: No; REACH SVHC: No SVHC; Turn-On Delay Time: 15ns; Weight: 0.032734oz; Width: 6.35mm
U10 = CMP-ICs-74xx-series-00079-1: Binary Full Adder Single 4-Bit 16-Pin PDIP Box; High Level Output Current: -400uA; Low Level Output Current: 8mA; Max Supply Voltage: 5.25V; Min Supply Voltage: 4.75V; Number of Bits: 4; Operating Supply Voltage: 5V; Case/Package: PDIP; Component Kind: Standard; Lead Free: Lead Free; Library Name: PCB-Tech Cloud; Logic Function: Binary Full Adder; Max Operating Temperature: 70°C; Min Operating Temperature: 0°C; Mount: Through Hole; Number of Pins: 16; Packaging: Box; REACH SVHC: No SVHC; RoHS Compliant: No
U13 = CMP-ICs-00009-1: IC MPU Z80 8MHZ 40DIP; Core Architecture: Z8; Data Bus Width: 8b; Max Supply Voltage: 5V; Memory Size: 64KB; Memory Type: ROMless; Min Supply Voltage: 4.5V; Number of I/Os: 24; Case/Package: DIP; Component Kind: Standard; Frequency: 8MHz; Height: 4.19mm; Lead Free: Lead Free; Length: 62.74mm; Library Name: PCB-Tech Cloud; Max Frequency: 8MHz; Max Operating Temperature: 100°C; Min Operating Temperature: -40°C; Mount: Through Hole; Number of Pins: 40; Package Quantity: 10; Packaging: Rail/Tube; Radiation Hardening: No; REACH SVHC: Unknown; Voltage: 5V; Width: 14.22mm
